# Altium schematic sheet Nixie_DRV_v2.SchDoc(Nixie_DRV_v2)
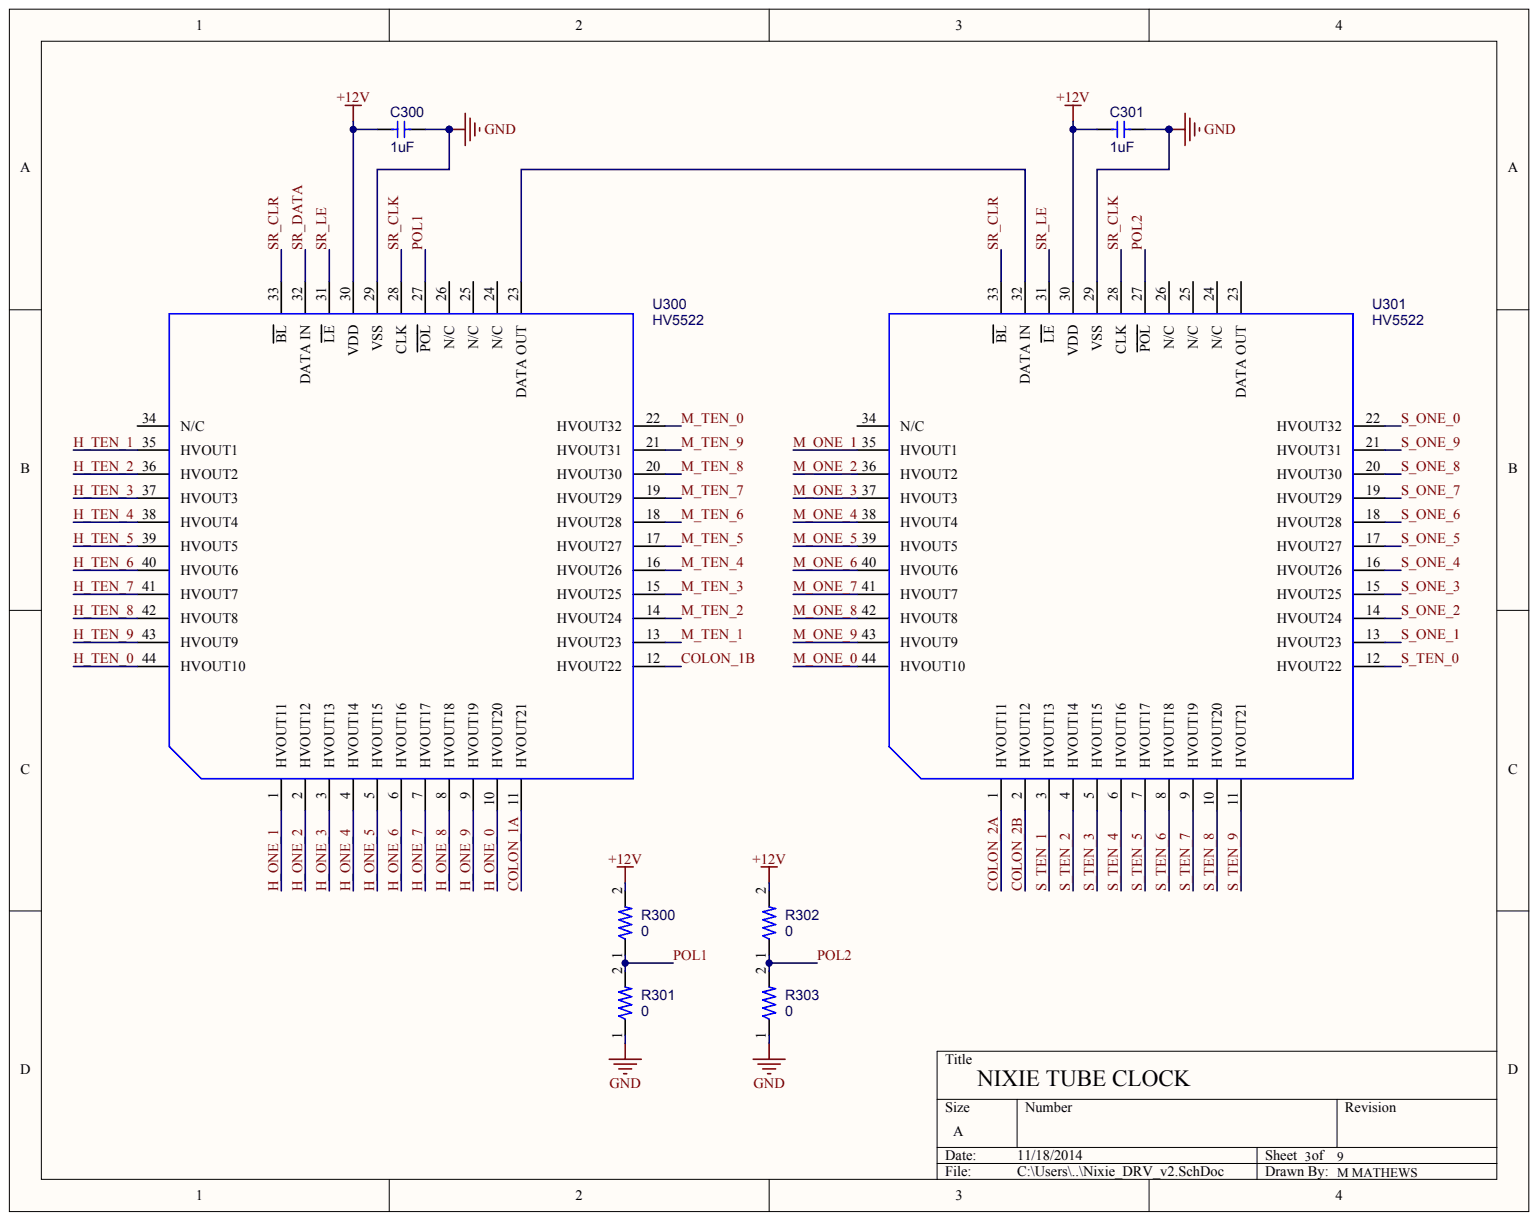
[diagram p0: Nixie_DRV_v2.SchDoc]

## Parts
C300  value 1uF  (hidden comment: CAP)
C301  value 1uF  (hidden comment: CAP)
R300  value 0  (hidden comment: RES)
R301  value 0  (hidden comment: RES)
R302  value 0  (hidden comment: RES)
R303  value 0  (hidden comment: RES)
U300  HV5522
U301  HV5522

## Nets
+12V: C300.1 C301.1 R300.2 R302.2 U300.30(VDD) U301.30(VDD)
GND: C300.2 C301.2 R301.1 R303.1 U300.29(VSS) U301.29(VSS)
COLON_1A: U300.11(HVOUT21)
COLON_1B: U300.12(HVOUT22)
COLON_2A: U301.1(HVOUT11)
COLON_2B: U301.2(HVOUT12)
H_ONE_0: U300.10(HVOUT20)
H_ONE_1: U300.1(HVOUT11)
H_ONE_2: U300.2(HVOUT12)
H_ONE_3: U300.3(HVOUT13)
H_ONE_4: U300.4(HVOUT14)
H_ONE_5: U300.5(HVOUT15)
H_ONE_6: U300.6(HVOUT16)
H_ONE_7: U300.7(HVOUT17)
H_ONE_8: U300.8(HVOUT18)
H_ONE_9: U300.9(HVOUT19)
H_TEN_0: U300.44(HVOUT10)
H_TEN_1: U300.35(HVOUT1)
H_TEN_2: U300.36(HVOUT2)
H_TEN_3: U300.37(HVOUT3)
H_TEN_4: U300.38(HVOUT4)
H_TEN_5: U300.39(HVOUT5)
H_TEN_6: U300.40(HVOUT6)
H_TEN_7: U300.41(HVOUT7)
H_TEN_8: U300.42(HVOUT8)
H_TEN_9: U300.43(HVOUT9)
M_ONE_0: U301.44(HVOUT10)
M_ONE_1: U301.35(HVOUT1)
M_ONE_2: U301.36(HVOUT2)
M_ONE_3: U301.37(HVOUT3)
M_ONE_4: U301.38(HVOUT4)
M_ONE_5: U301.39(HVOUT5)
M_ONE_6: U301.40(HVOUT6)
M_ONE_7: U301.41(HVOUT7)
M_ONE_8: U301.42(HVOUT8)
M_ONE_9: U301.43(HVOUT9)
M_TEN_0: U300.22(HVOUT32)
M_TEN_1: U300.13(HVOUT23)
M_TEN_2: U300.14(HVOUT24)
M_TEN_3: U300.15(HVOUT25)
M_TEN_4: U300.16(HVOUT26)
M_TEN_5: U300.17(HVOUT27)
M_TEN_6: U300.18(HVOUT28)
M_TEN_7: U300.19(HVOUT29)
M_TEN_8: U300.20(HVOUT30)
M_TEN_9: U300.21(HVOUT31)
POL1: R300.1 R301.2 U300.27(POL)
POL2: R302.1 R303.2 U301.27(POL)
S_ONE_0: U301.22(HVOUT32)
S_ONE_1: U301.13(HVOUT23)
S_ONE_2: U301.14(HVOUT24)
S_ONE_3: U301.15(HVOUT25)
S_ONE_4: U301.16(HVOUT26)
S_ONE_5: U301.17(HVOUT27)
S_ONE_6: U301.18(HVOUT28)
S_ONE_7: U301.19(HVOUT29)
S_ONE_8: U301.20(HVOUT30)
S_ONE_9: U301.21(HVOUT31)
S_TEN_0: U301.12(HVOUT22)
S_TEN_1: U301.3(HVOUT13)
S_TEN_2: U301.4(HVOUT14)
S_TEN_3: U301.5(HVOUT15)
S_TEN_4: U301.6(HVOUT16)
S_TEN_5: U301.7(HVOUT17)
S_TEN_6: U301.8(HVOUT18)
S_TEN_7: U301.9(HVOUT19)
S_TEN_8: U301.10(HVOUT20)
S_TEN_9: U301.11(HVOUT21)
SR_CLK: U300.28(CLK) U301.28(CLK)
SR_CLR: U300.33(BL) U301.33(BL)
SR_DATA: U300.32(DATA_IN)
SR_LE: U300.31(LE) U301.31(LE)
NetU300_23: U300.23(DATA_OUT) U301.32(DATA_IN)

Not connected: U300 24(N/C) 25(N/C) 26(N/C) 34(N/C); U301 23(DATA_OUT) 24(N/C) 25(N/C) 26(N/C) 34(N/C)

## Library data of the parts
C300 C301 = (no symbol name): Manufacturer: Samsung; Manufacturer Part Number: CL21B105KBFNNNE; Tolerance: 10%; Voltage: 50V; Revision: A; Component Kind: Standard; Cost: 0.071; Supplier 1: Digi-key; Supplier Part Number 1: 1276-1029-1-ND; IPC Pattern: 0805
R300-R303 = (no symbol name): Manufacturer: Panasonic; Manufacturer Part Number: ERJ-3GEY0R00V; Tolerance: -; Voltage: -; Revision: A; Cost: 0.0096; Supplier 1: Digi-key; Supplier Part Number 1: P0.0GCT-ND; Component Kind: Standard; IPC Pattern: 0603
U300 U301 = (no symbol name): Manufacturer: Supertex; Manufacturer Part Number: HV5522PG-G; Revision: A; Component Kind: Standard; Cost: 7.36; Supplier 1: Digi-key; Supplier Part Number 1: HV5522PG-G-ND; Tolerance: -; Value: -; Voltage: -; IPC Pattern: 44-PQFP
